# Altium schematic sheet IO_Converter.SchDoc(IO_Converter)
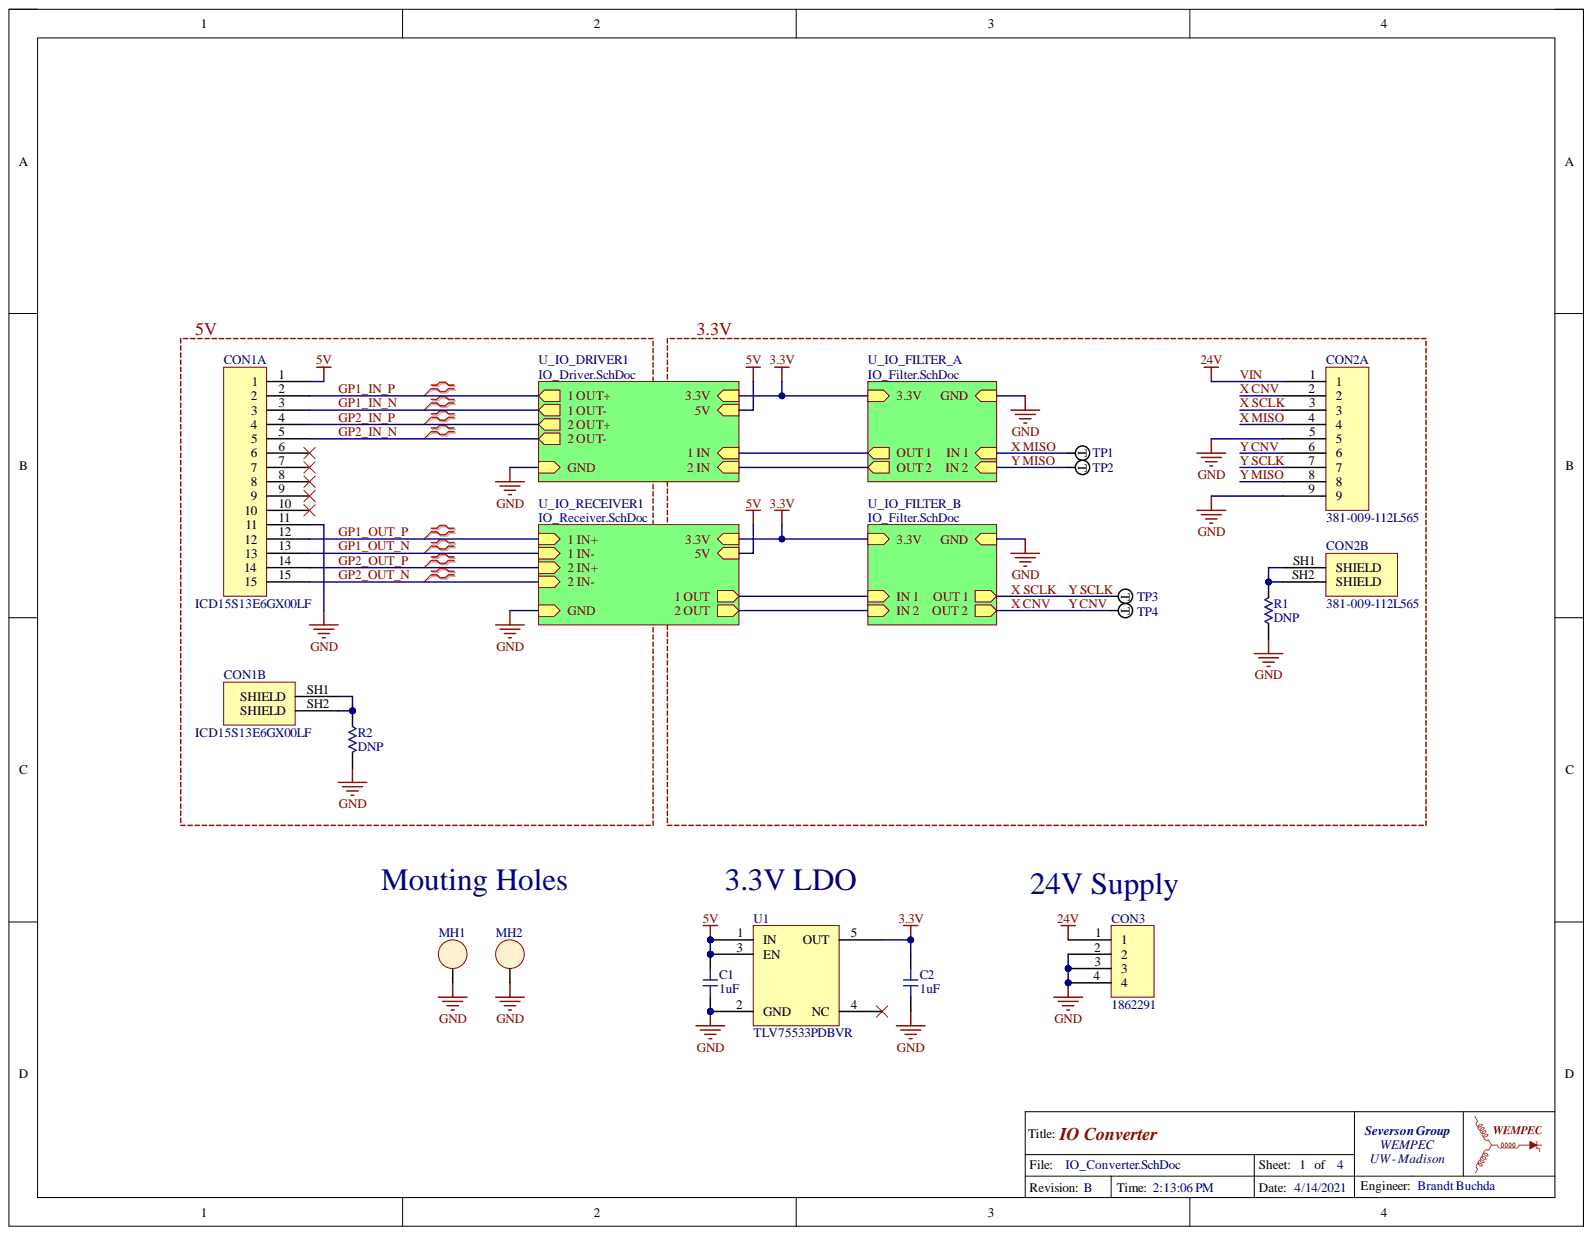
[diagram p0: IO_Converter.SchDoc]

## Parts
C1  value 1uF  [0603-CAP]  (hidden comment: CL10A105KP8NNWC)
C2  value 1uF  [0603-CAP]  (hidden comment: CL10A105KP8NNWC)
CON1  ICD15S13E6GX00LF  [ICD15S13E6GX00LF]  (parts A,B)
CON2  381-009-112L565  [381-009-112L565]  (parts A,B)
CON3  1862291  [1862291]
MH1  MOUNT_HOLE  [MOUNTING_HOLE]  (value not drawn)
MH2  MOUNT_HOLE  [MOUNTING_HOLE]  (value not drawn)
R1  value DNP  [0603-RES]  (hidden comment: Resistor)  (no BOM)
R2  value DNP  [0603-RES]  (hidden comment: Resistor)  (no BOM)
TP1  RCU-0C  (value not drawn)
TP2  RCU-0C  (value not drawn)
TP3  RCU-0C  (value not drawn)
TP4  RCU-0C  (value not drawn)
U1  TLV75533PDBVR  [SOT95P280X145-5N]

## Nets
3.3V: C2.2 U1.5(OUT)
5V: C1.2 CON1.1 U1.1(IN) U1.3(EN)
GND: C1.1 C2.1 CON1.11 CON2.5 CON2.9 CON3.2 CON3.3 CON3.4 MH1.1 MH2.1 R1.2 R2.2 U1.2(GND)
GP1_IN_N: CON1.3
GP1_IN_P: CON1.2
GP1_OUT_N: CON1.13
GP1_OUT_P: CON1.12
GP2_IN_N: CON1.5
GP2_IN_P: CON1.4
GP2_OUT_N: CON1.15
GP2_OUT_P: CON1.14
VIN: CON2.1 CON3.1
X CNV: CON2.2 CON2.6 TP4.1
X MISO: CON2.4 TP1.1
X SCLK: CON2.3 CON2.7 TP3.1
Y MISO: CON2.8 TP2.1
NetCON1_6: CON1.6
NetCON1_7: CON1.7
NetCON1_8: CON1.8
NetCON1_9: CON1.9
NetCON1_10: CON1.10
NetCON1_SH1: CON1.SH1(SHIELD) CON1.SH2(SHIELD) R2.1
NetCON2_SH1: CON2.SH1(SHIELD) CON2.SH2(SHIELD) R1.1
NetU1_4: U1.4(NC)
1 IN:
1 OUT:
2 IN:
2 OUT:

## Library data of the parts
C1 C2 = Capacitor 1uF: CAP CER 1UF 10V X5R 0603; Component Kind: Standard; Library Name: IO_Converter.SchLib; Simulation: CAP; Supplier 1: Digi-Key; Supplier Part Number 1: 1276-1863-1-ND
CON1 = ICD15S13E6GX00LF: 15 Position D-Sub, High Density Receptacle, Female Sockets Connector; Component Kind: Standard; Supplier 1: Digi-Key; Supplier Part Number 1: 609-2801-ND; Library Name: CRAMB.SchLib
CON2 = 381-009-112L565: 9 Position D-Type, Micro-D Plug, Male Pins Connector; Component Kind: Standard; Library Name: IO_Converter.SchLib; Supplier 1: DigiKey; Supplier Part Number 1: NOR1136-ND
CON3 = 1862291: TERM BLOCK 4POS 45DEG 5MM PCB; Component Kind: Standard; Library Name: IO_Converter.SchLib; Supplier 1: DigiKey; Supplier Part Number 1: 277-12141-ND
MH1 MH2 = MOUNT_HOLE: MOUNTING HOLE; Component Kind: Standard; Library Name: IO_Converter.SchLib
R1 R2 = Resistor: DNP; Simulation: RES; Supplier 1: Digi-Key; Library Name: CRAMB.SchLib
TP1-TP4 = Test Point: PC TEST POINT NATURAL; Material - Insulation: No Insulation; Category: Test and Measurement; Color: Natural; Component Kind: Standard; ComponentLink1Description: http://www.te.com/commerce/DocumentDelivery/DDEController?Action=srchrtrv&DocNm=1773266&DocType=DS&DocLang=English; ComponentLink1URL: http://www.te.com/commerce/DocumentDelivery/DDEController?Action=srchrtrv&DocNm=1773266&DocType=DS&DocLang=English; Library Name: IO_Converter.SchLib; Manufacturer Part Number: RCU-0C; Material - Body: -; Mounting Type: Surface Mount; Packaging: Cut Tape (CT); Part Status: Active; Plating: -; Pricing 1: 1=0.22, 10=0.206, 25=0.1756, 50=0.1536, 100=0.1426, 250=0.12616, 500=0.12068, 1000=0.09874 (USD); RoHS: RoHS Compliant; Size / Dimension: 0.063" x 0.031" x 0.045" (1.60mm x 0.80mm x 1.15mm); Stock 1: 136646; Supplier 1: Digi-Key; Supplier Part Number 1: A106145CT-ND; Type: PC Test Point
U1 = TLV75533PDBVR: IC REG LINEAR 3.3V 500MA SOT23-5; Component Kind: Standard; Library Name: IO_Converter.SchLib; Supplier 1: Digi-Key; Supplier Part Number 1: 296-50411-1-ND
